# Altium schematic sheet HYPNOSIA_controller_V1.SchDot(HYPNOSIA_controller_V1)
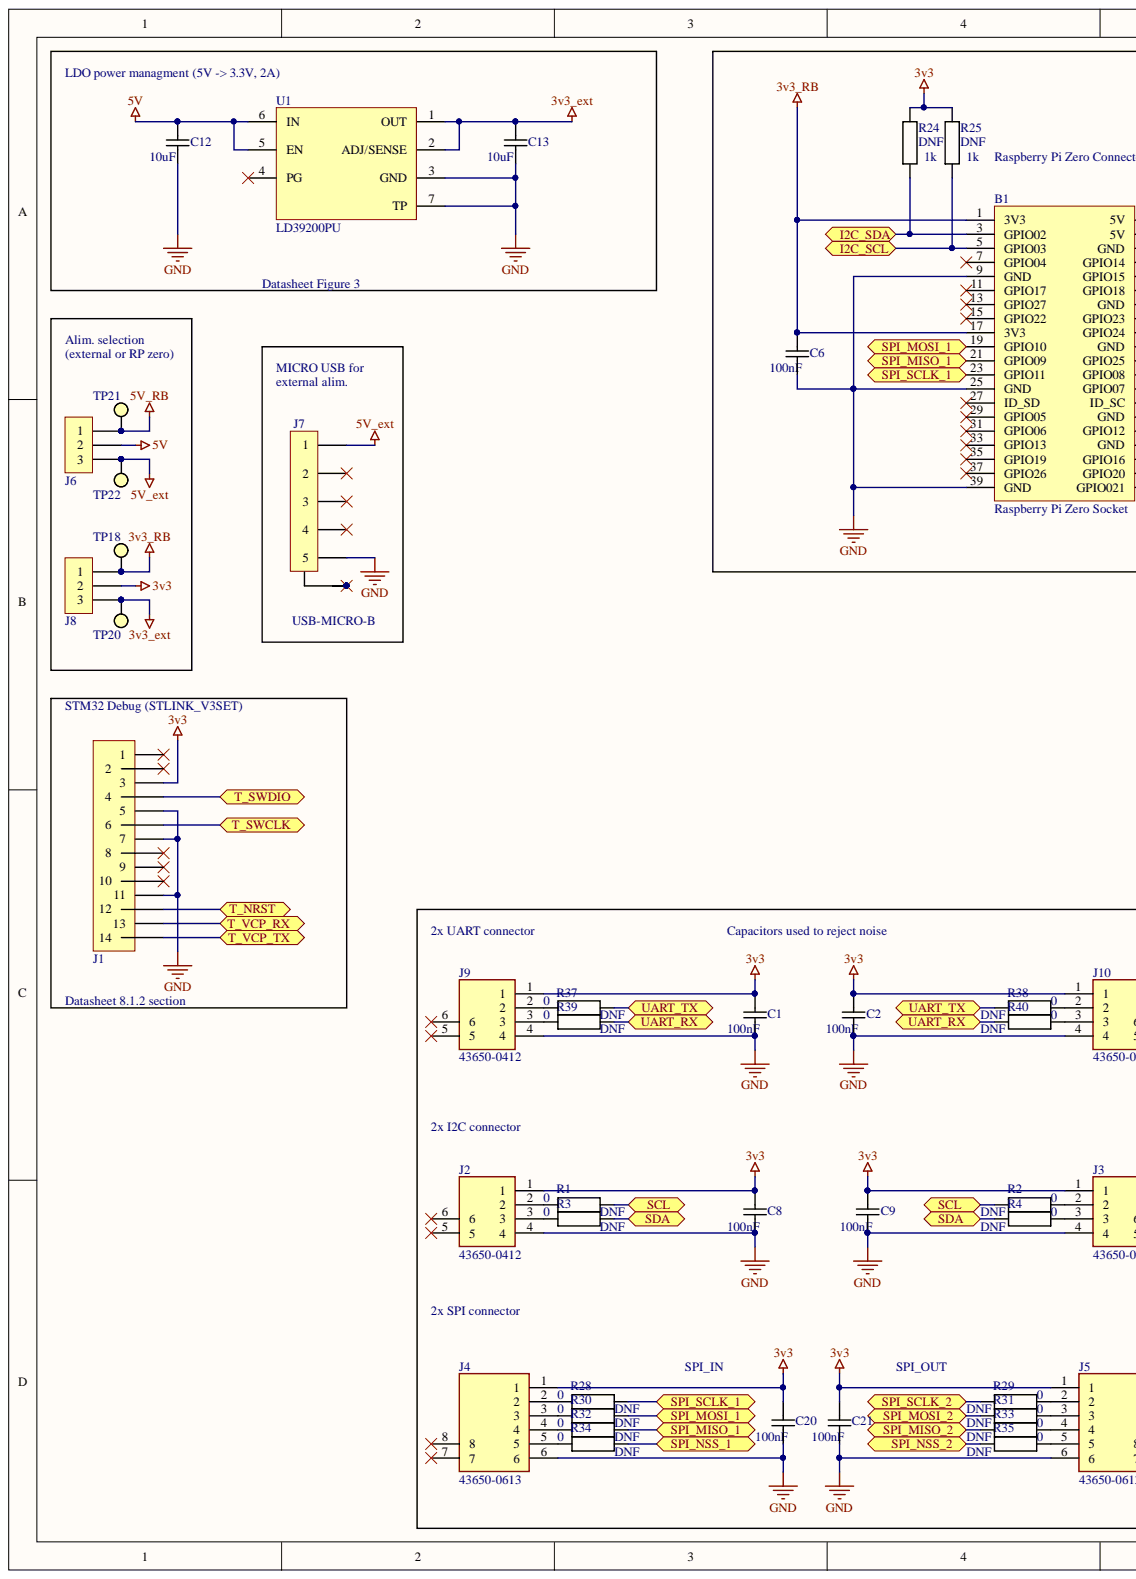
[diagram p0: HYPNOSIA_controller_V1.SchDot(HYPNOSIA_controller_V1), left]
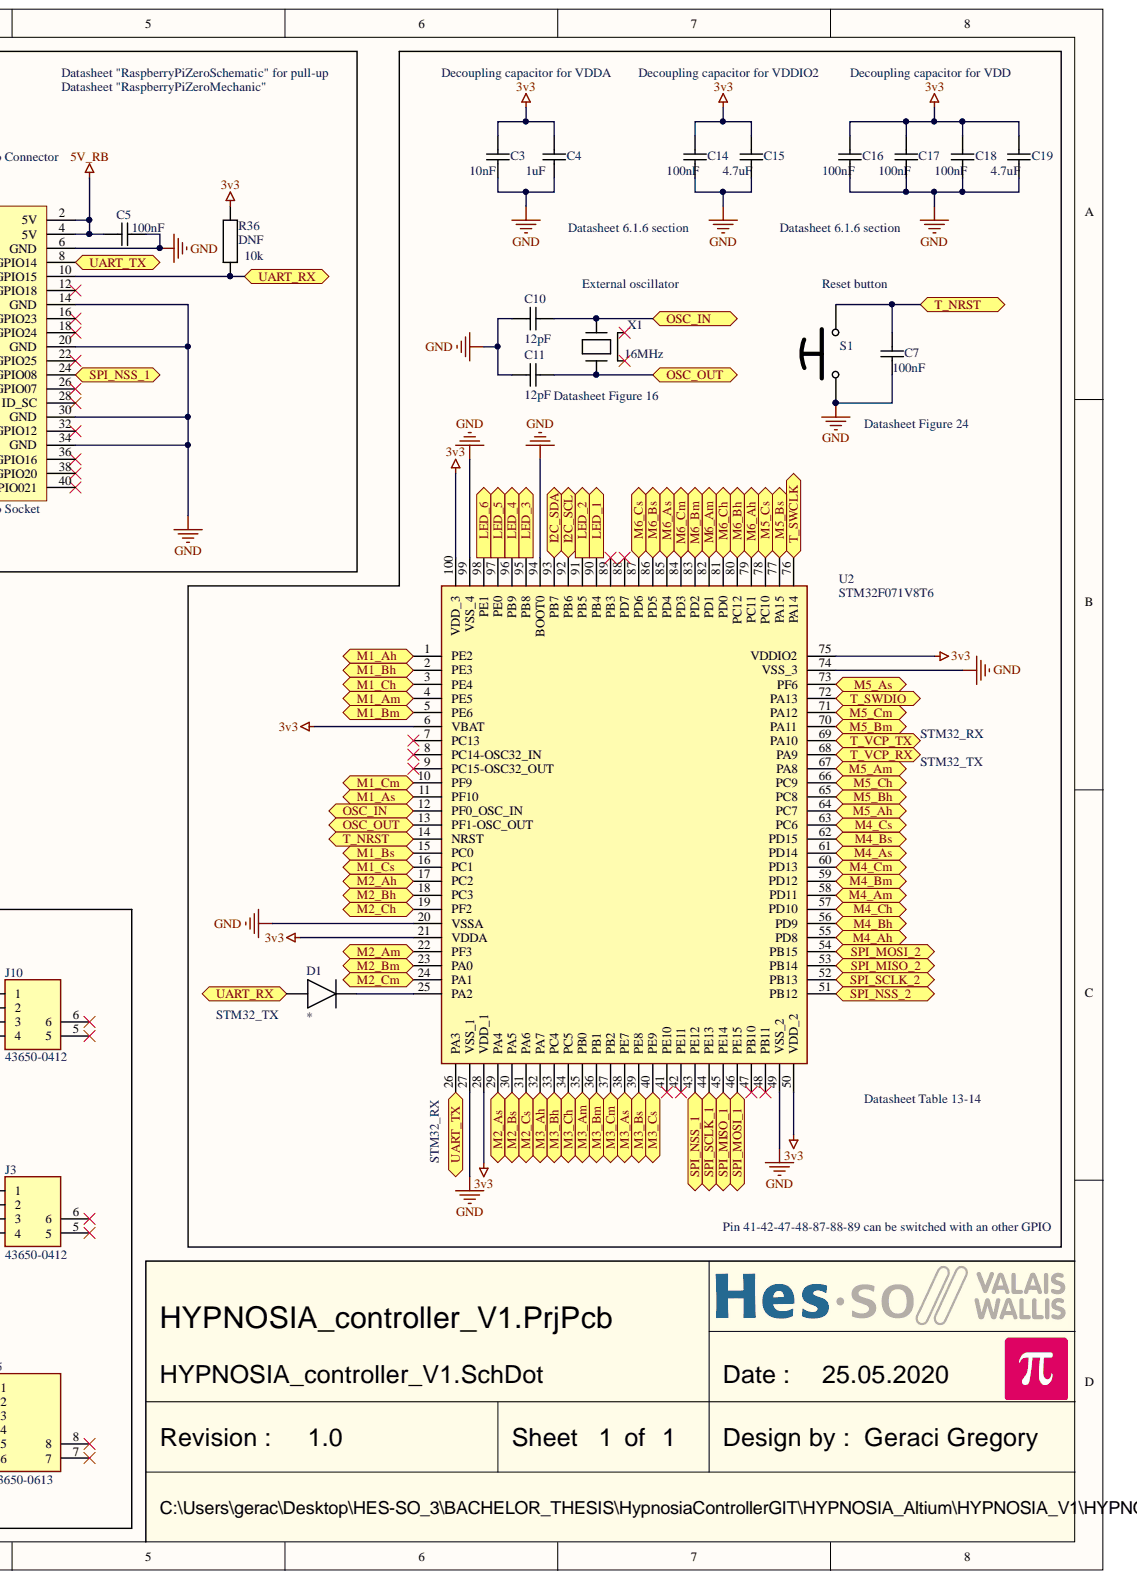
[diagram p1: HYPNOSIA_controller_V1.SchDot(HYPNOSIA_controller_V1), right]

## Parts
B1  Raspberry Pi Zero Socket  [Raspberry Pi Zero Socket SMD]
C1  value 100nF  [CAPACITOR 0603 REFLOW]
C2  value 100nF  [CAPACITOR 0603 REFLOW]
C3  value 10nF  [CAPACITOR 0603 REFLOW]
C4  value 1uF  [CAPACITOR 0603 REFLOW]
C5  value 100nF  [CAPACITOR 0603 REFLOW]
C6  value 100nF  [CAPACITOR 0603 REFLOW]
C7  value 100nF  [CAPACITOR 0603 REFLOW]
C8  value 100nF  [CAPACITOR 0603 REFLOW]
C9  value 100nF  [CAPACITOR 0603 REFLOW]
C10  value 12pF  [CAPACITOR 0603 REFLOW]
C11  value 12pF  [CAPACITOR 0603 REFLOW]
C12  value 10uF  [CAPACITOR 0603 REFLOW]
C13  value 10uF  [CAPACITOR 0603 REFLOW]
C14  value 100nF  [CAPACITOR 0603 REFLOW]
C15  value 4.7uF  [CAPACITOR 0603 REFLOW]
C16  value 100nF  [CAPACITOR 0603 REFLOW]
C17  value 100nF  [CAPACITOR 0603 REFLOW]
C18  value 100nF  [CAPACITOR 0603 REFLOW]
C19  value 4.7uF  [CAPACITOR 0603 REFLOW]
C20  value 100nF  [CAPACITOR 0603 REFLOW]
C21  value 100nF  [CAPACITOR 0603 REFLOW]
D1  (no value)  [DIODE SOD123-FL REFLOW]
J1  (no value)  [PinHeader2x7 P1.27 SMD H5.9]
J2  43650-0412  [MOLEX 43650-0412]
J3  43650-0412  [MOLEX 43650-0412]
J4  43650-0613  [MOLEX 43650-0613]
J5  43650-0613  [MOLEX 43650-0613]
J6  (no value)  [PinHeader1x3 P2.54 A SMD]
J7  USB-MICRO-B  [USB-MICRO-B HIROSE ZX62D-B-5PA8]
J8  (no value)  [PinHeader1x3 P2.54 A SMD]
J9  43650-0412  [MOLEX 43650-0412]
J10  43650-0412  [MOLEX 43650-0412]
R1  DNF  value 0  [RESISTOR 0603 REFLOW]
R2  DNF  value 0  [RESISTOR 0603 REFLOW]
R3  DNF  value 0  [RESISTOR 0603 REFLOW]
R4  DNF  value 0  [RESISTOR 0603 REFLOW]
R24  DNF  value 1k  [RESISTOR 0603 REFLOW]
R25  DNF  value 1k  [RESISTOR 0603 REFLOW]
R28  DNF  value 0  [RESISTOR 0603 REFLOW]
R29  DNF  value 0  [RESISTOR 0603 REFLOW]
R30  DNF  value 0  [RESISTOR 0603 REFLOW]
R31  DNF  value 0  [RESISTOR 0603 REFLOW]
R32  DNF  value 0  [RESISTOR 0603 REFLOW]
R33  DNF  value 0  [RESISTOR 0603 REFLOW]
R34  DNF  value 0  [RESISTOR 0603 REFLOW]
R35  DNF  value 0  [RESISTOR 0603 REFLOW]
R36  DNF  value 10k  [RESISTOR 0603 REFLOW]
R37  DNF  value 0  [RESISTOR 0603 REFLOW]
R38  DNF  value 0  [RESISTOR 0603 REFLOW]
R39  DNF  value 0  [RESISTOR 0603 REFLOW]
R40  DNF  value 0  [RESISTOR 0603 REFLOW]
S1  (no value)  [Push Button C2.5X3.0 OMRON B3U-1000P(M)-B]
TP18  (no value)  [Test Point ARWIN S1751-46R]
TP20  (no value)  [Test Point ARWIN S1751-46R]
TP21  (no value)  [Test Point ARWIN S1751-46R]
TP22  (no value)  [Test Point ARWIN S1751-46R]
U1  LD39200PU  [DFN6 P0.95 T1.75X2.50 C3.0X3.0 H1.0]
U2  STM32F071V8T6  [LQFP100 P0.5 C14.0X14.0 H1.6]
X1  16MHz  [Crystal C2.5x2 Seiko Epson FA-128]

## Ports
I2C_SCL, I2C_SDA, LED_1, LED_2, LED_3, LED_4, LED_5, LED_6, M1_Ah, M1_Am, M1_As, M1_Bh, M1_Bm, M1_Bs, M1_Ch, M1_Cm, M1_Cs, M2_Ah, M2_Am, M2_As, M2_Bh, M2_Bm, M2_Bs, M2_Ch, M2_Cm, M2_Cs, M3_Ah, M3_Am, M3_As, M3_Bh, M3_Bm, M3_Bs, M3_Ch, M3_Cm, M3_Cs, M4_Ah, M4_Am, M4_As, M4_Bh, M4_Bm, M4_Bs, M4_Ch, M4_Cm, M4_Cs, M5_Ah, M5_Am, M5_As, M5_Bh, M5_Bm, M5_Bs, M5_Ch, M5_Cm, M5_Cs, M6_Ah, M6_Am, M6_As, M6_Bh, M6_Bm, M6_Bs, M6_Ch, M6_Cm, M6_Cs, OSC_IN, OSC_OUT, SCL, SDA, SPI_MISO_1, SPI_MISO_2, SPI_MOSI_1, SPI_MOSI_2, SPI_NSS_1, SPI_NSS_2, SPI_SCLK_1, SPI_SCLK_2, T_NRST, T_SWCLK, T_SWDIO, T_VCP_RX, T_VCP_TX, UART_RX, UART_TX

## Nets
3v3: C1.2 C2.2 C3.2 C4.2 C8.2 C9.2 C14.2 C15.2 C16.2 C17.2 C18.2 C19.2 C20.2 C21.2 J1.3 J2.1 J3.1 J4.1 J5.1 J8.2 J9.1 J10.1 R24.2 R25.2 R36.2 U2.6(VBAT) U2.21(VDDA) U2.28(VDD_1) U2.50(VDD_2) U2.75(VDDIO2) U2.100(VDD_3)
3v3_ext: C13.2 J8.3 TP20.1 U1.1(OUT) U1.2(ADJ/SENSE)
3v3_RB: B1.1(3V3) B1.17(3V3) C6.2 J8.1 TP18.1
5V: C12.2 J6.2 U1.5(EN) U1.6(IN)
5V_ext: J6.3 J7.1 TP22.1
5V_RB: B1.2(5V) B1.4(5V) C5.2 J6.1 TP21.1
GND: B1.6(GND) B1.9(GND) B1.14(GND) B1.20(GND) B1.25(GND) B1.30(GND) B1.34(GND) B1.39(GND) C1.1 C2.1 C3.1 C4.1 C5.1 C6.1 C7.1 C8.1 C9.1 C10.2 C11.2 C12.1 C13.1 C14.1 C15.1 C16.1 C17.1 C18.1 C19.1 C20.1 C21.1 J1.5 J1.7 J1.11 J2.4 J3.4 J4.6 J5.6 J7.5 J9.4 J10.4 S1.1 U1.3(GND) U1.7(TP) U2.20(VSSA) U2.27(VSS_1) U2.49(VSS_2) U2.74(VSS_3) U2.94(BOOT0) U2.99(VSS_4)
I2C_SCL: B1.5(GPIO03) R25.1 U2.92(PB6) ; port I2C_SCL
I2C_SDA: B1.3(GPIO02) R24.1 U2.93(PB7) ; port I2C_SDA
LED_1: U2.90(PB4) ; port LED_1
LED_2: U2.91(PB5) ; port LED_2
LED_3: U2.95(PB8) ; port LED_3
LED_4: U2.96(PB9) ; port LED_4
LED_5: U2.97(PE0) ; port LED_5
LED_6: U2.98(PE1) ; port LED_6
M1_Ah: U2.1(PE2) ; port M1_Ah
M1_Am: U2.4(PE5) ; port M1_Am
M1_As: U2.11(PF10) ; port M1_As
M1_Bh: U2.2(PE3) ; port M1_Bh
M1_Bm: U2.5(PE6) ; port M1_Bm
M1_Bs: U2.15(PC0) ; port M1_Bs
M1_Ch: U2.3(PE4) ; port M1_Ch
M1_Cm: U2.10(PF9) ; port M1_Cm
M1_Cs: U2.16(PC1) ; port M1_Cs
M2_Ah: U2.17(PC2) ; port M2_Ah
M2_Am: U2.22(PF3) ; port M2_Am
M2_As: U2.29(PA4) ; port M2_As
M2_Bh: U2.18(PC3) ; port M2_Bh
M2_Bm: U2.23(PA0) ; port M2_Bm
M2_Bs: U2.30(PA5) ; port M2_Bs
M2_Ch: U2.19(PF2) ; port M2_Ch
M2_Cm: U2.24(PA1) ; port M2_Cm
M2_Cs: U2.31(PA6) ; port M2_Cs
M3_Ah: U2.32(PA7) ; port M3_Ah
M3_Am: U2.35(PB0) ; port M3_Am
M3_As: U2.38(PE7) ; port M3_As
M3_Bh: U2.33(PC4) ; port M3_Bh
M3_Bm: U2.36(PB1) ; port M3_Bm
M3_Bs: U2.39(PE8) ; port M3_Bs
M3_Ch: U2.34(PC5) ; port M3_Ch
M3_Cm: U2.37(PB2) ; port M3_Cm
M3_Cs: U2.40(PE9) ; port M3_Cs
M4_Ah: U2.55(PD8) ; port M4_Ah
M4_Am: U2.58(PD11) ; port M4_Am
M4_As: U2.61(PD14) ; port M4_As
M4_Bh: U2.56(PD9) ; port M4_Bh
M4_Bm: U2.59(PD12) ; port M4_Bm
M4_Bs: U2.62(PD15) ; port M4_Bs
M4_Ch: U2.57(PD10) ; port M4_Ch
M4_Cm: U2.60(PD13) ; port M4_Cm
M4_Cs: U2.63(PC6) ; port M4_Cs
M5_Ah: U2.64(PC7) ; port M5_Ah
M5_Am: U2.67(PA8) ; port M5_Am
M5_As: U2.73(PF6) ; port M5_As
M5_Bh: U2.65(PC8) ; port M5_Bh
M5_Bm: U2.70(PA11) ; port M5_Bm
M5_Bs: U2.77(PA15) ; port M5_Bs
M5_Ch: U2.66(PC9) ; port M5_Ch
M5_Cm: U2.71(PA12) ; port M5_Cm
M5_Cs: U2.78(PC10) ; port M5_Cs
M6_Ah: U2.79(PC11) ; port M6_Ah
M6_Am: U2.82(PD1) ; port M6_Am
M6_As: U2.85(PD4) ; port M6_As
M6_Bh: U2.80(PC12) ; port M6_Bh
M6_Bm: U2.83(PD2) ; port M6_Bm
M6_Bs: U2.86(PD5) ; port M6_Bs
M6_Ch: U2.81(PD0) ; port M6_Ch
M6_Cm: U2.84(PD3) ; port M6_Cm
M6_Cs: U2.87(PD6) ; port M6_Cs
OSC_IN: C10.1 U2.12(PF0_OSC_IN) X1.3 ; port OSC_IN
OSC_OUT: C11.1 U2.13(PF1-OSC_OUT) X1.1 ; port OSC_OUT
SCL: R1.2 R2.2 ; port SCL
SDA: R3.2 R4.2 ; port SDA
SPI_MISO_1: B1.21(GPIO09) R32.2 U2.45(PE14) ; port SPI_MISO_1
SPI_MISO_2: R33.2 U2.53(PB14) ; port SPI_MISO_2
SPI_MOSI_1: B1.19(GPIO10) R30.2 U2.46(PE15) ; port SPI_MOSI_1
SPI_MOSI_2: R31.2 U2.54(PB15) ; port SPI_MOSI_2
SPI_NSS_1: B1.24(GPIO08) R34.2 U2.43(PE12) ; port SPI_NSS_1
SPI_NSS_2: R35.2 U2.51(PB12) ; port SPI_NSS_2
SPI_SCLK_1: B1.23(GPIO11) R28.2 U2.44(PE13) ; port SPI_SCLK_1
SPI_SCLK_2: R29.2 U2.52(PB13) ; port SPI_SCLK_2
T_NRST: C7.2 J1.12 S1.2 U2.14(NRST) ; port T_NRST
T_SWCLK: J1.6 U2.76(PA14) ; port T_SWCLK
T_SWDIO: J1.4 U2.72(PA13) ; port T_SWDIO
T_VCP_RX: J1.13 U2.68(PA9) ; port T_VCP_RX
T_VCP_TX: J1.14 U2.69(PA10) ; port T_VCP_TX
UART_RX: B1.10(GPIO15) D1.2 R36.1 R39.2 R40.2 ; port UART_RX
UART_TX: B1.8(GPIO14) R37.2 R38.2 U2.26(PA3) ; port UART_TX
NetD1_1: D1.1 U2.25(PA2)
NetJ2_2: J2.2 R1.1
NetJ2_3: J2.3 R3.1
NetJ3_2: J3.2 R2.1
NetJ3_3: J3.3 R4.1
NetJ4_2: J4.2 R28.1
NetJ4_3: J4.3 R30.1
NetJ4_4: J4.4 R32.1
NetJ4_5: J4.5 R34.1
NetJ5_2: J5.2 R29.1
NetJ5_3: J5.3 R31.1
NetJ5_4: J5.4 R33.1
NetJ5_5: J5.5 R35.1
NetJ7_6: J7.6 J7.7 J7.8 J7.9
NetJ9_2: J9.2 R37.1
NetJ9_3: J9.3 R39.1
NetJ10_2: J10.2 R38.1
NetJ10_3: J10.3 R40.1

Not connected: B1 7(GPIO04) 11(GPIO17) 12(GPIO18) 13(GPIO27) 15(GPIO22) 16(GPIO23) 18(GPIO24) 22(GPIO25) 26(GPIO07) 27(ID_SD) 28(ID_SC) 29(GPIO05) 31(GPIO06) 32(GPIO12) 33(GPIO13) 35(GPIO19) 36(GPIO16) 37(GPIO26) 38(GPIO20) 40(GPIO021); J1 1 2 8 9 10; J2 5 6; J3 5 6; J4 7 8; J5 7 8; J7 2 3 4; J9 5 6; J10 5 6; U1 4(PG); U2 7(PC13) 8(PC14-OSC32_IN) 9(PC15-OSC32_OUT) 41(PE10) 42(PE11) 47(PB10) 48(PB11) 88(PD7) 89(PB3); X1 2 4

## Library data of the parts
B1 = Raspberry Pi Zero Socket: Small single-board computer; Value: Raspberry Pi Zero Socket; Library Name: DevBoard.IntLib; Component Kind: Standard
C1-C21 = Capacitor: Library Name: Capacitor.IntLib; Description: Capacitor; Component Kind: Standard
D1 = DIODE: Diode generic 2 leads; Library Name: Diode.IntLib; Component Kind: Standard
J1 = PinHeader2x7: Plug connector; Library Name: PinHeader.IntLib; Component Kind: Standard
J2 J3 J9 J10 = MOLEX 43650-0412: MicroFit RA HDR 4Ckt SR SMT w/SldTab; Manufacturer: Molex; Value: 43650-0412; Mouser No: 538-43650-0412; Library Name: Connector.IntLib; Component Kind: Standard
J4 J5 = MOLEX 43650-0613: Micro-Fit 3.0 Right-Angle Header, 3.00mm Pitch, Single Row, 6 Circuits, with SolderTab, Gold, Glow-Wire Capable, Black; Manufacturer: Molex; Value: 43650-0613; Mouser No: 538-43650-0613; Library Name: Connector.IntLib; Component Kind: Standard
J6 J8 = PinHeader1x3: Plug connector; Library Name: PinHeader.IntLib; Component Kind: Standard
J7 = USB-MICRO-B HIROSE ZX62D-B-5PA8: Library Name: Connector.IntLib; Description: USB-MICRO-B HIROSE ZX62D-B-5PA8; Component Kind: Standard
R1-R4 R24 R25 R28-R40 = Resistor: Library Name: Resistor.IntLib; Description: Resistor; Component Kind: Standard
S1 = Push Button 2Pins: Push Button, 2 pins; Library Name: Button.IntLib; Component Kind: Standard
TP18 TP20-TP22 = Test Point: Library Name: Testpoint.IntLib; Description: Test Point; Component Kind: Standard
U1 = LD39200: 2 A high PSRR ultra low drop linear regulator with reverse current protection; Manufacturer: ST; Value: LD39200PU; Library Name: Regulator.IntLib; Component Kind: Standard
U2 = STM32F071V8T6: Arm-based 32-bit MCU, up to 128 KB Flash, 12 timers, ADC, DAC and communication interfaces, 2.0 - 3.6 V; Manufacturer: ST; Value: STM32F071V8T6; Mouser No: 511-STM32F071V8T6; Library Name: Processor.IntLib; Component Kind: Standard
X1 = Crystal C2.5x2 Seiko Epson FA-128: Value: 16MHz; Library Name: Oscillator.IntLib; Description: Crystal C2.5x2 Seiko Epson FA-128; Component Kind: Standard
